# Altium schematic sheet 24210B_KitDevESP32Gui.SchDoc
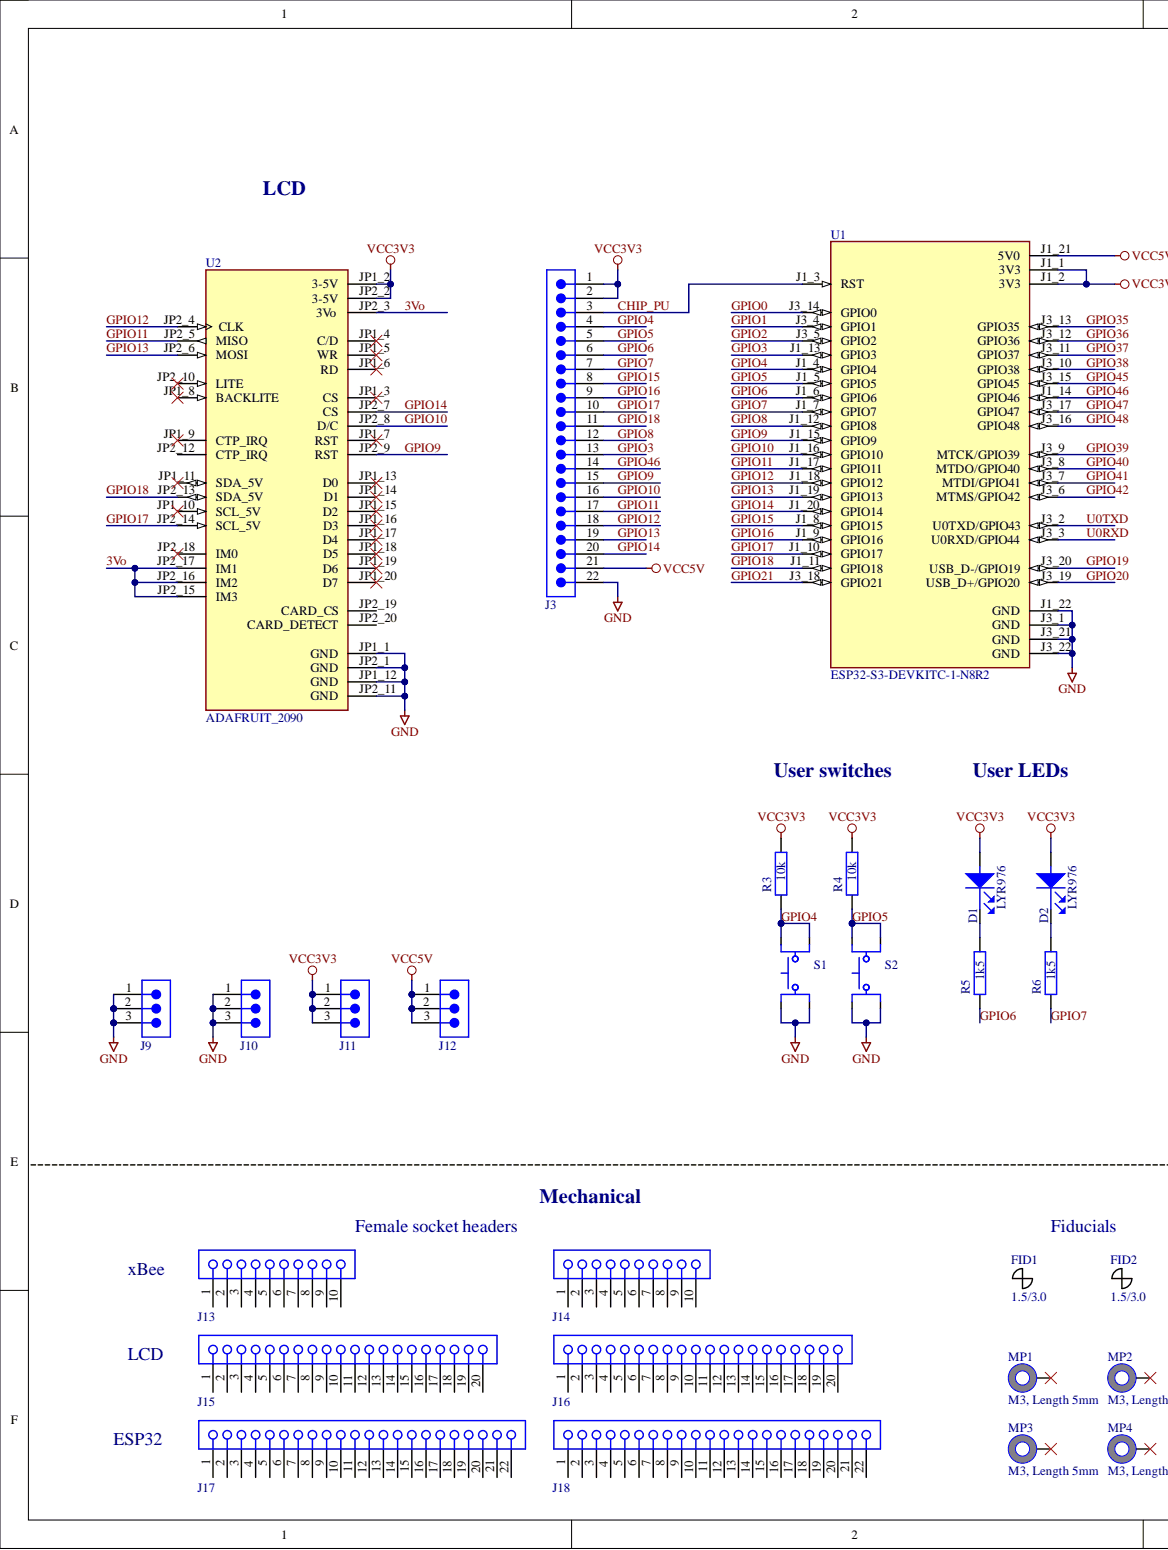
[diagram p0: 24210B_KitDevESP32Gui.SchDoc, left]
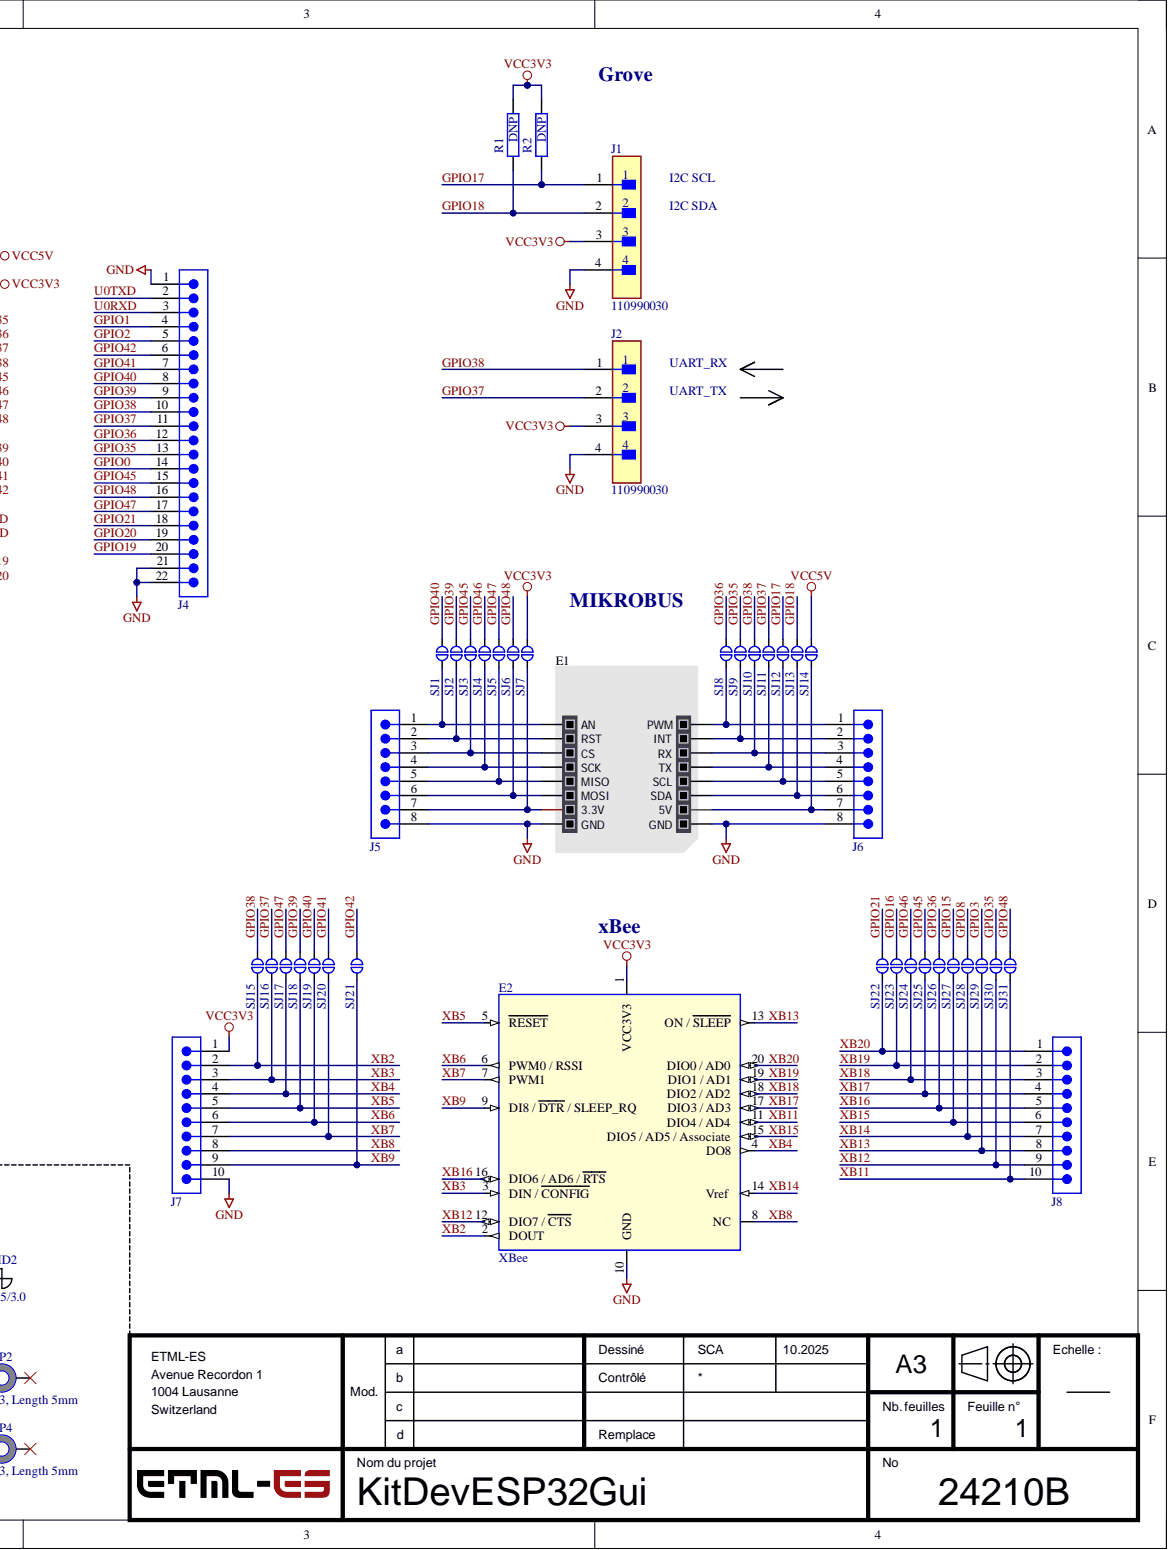
[diagram p1: 24210B_KitDevESP32Gui.SchDoc, right]

## Parts
D1  LYR976  [LED_0805]
D2  LYR976  [LED_0805]
E1  MIKROBUS HOST CONN.  [MIKROBUS HOST CONN. TH]  (value not drawn)
E2  XBee
FID1  1.5/3.0  [FID-150-300]  (no BOM)
FID2  1.5/3.0  SubCategory: Fiducials  [FID-150-300]  (no BOM)
J1  110990030  [FP-110990030-MFG]
J2  110990030  [FP-110990030-MFG]
J3  61302211121  [61302211121]  (value not drawn)
J4  61302211121  [61302211121]  (value not drawn)
J5  61300811121  [61300811121]  (value not drawn)
J6  61300811121  [61300811121]  (value not drawn)
J7  61301011121  [61301011121]  (value not drawn)
J8  61301011121  [61301011121]  (value not drawn)
J9  61300311121  [61300311121]  (value not drawn)
J10  61300311121  [61300311121]  (value not drawn)
J11  61300311121  [61300311121]  (value not drawn)
J12  61300311121  [61300311121]  (value not drawn)
J13  62001011821  [62001011821]  (value not drawn)  (mechanical)
J14  62001011821  [62001011821]  (value not drawn)  (mechanical)
J15  61302011821  [61302011821]  (value not drawn)  (mechanical)
J16  61302011821  [61302011821]  (value not drawn)  (mechanical)
J17  61302211821  [61302211821]  (value not drawn)  (mechanical)
J18  61302211821  [61302211821]  (value not drawn)  (mechanical)
MP1  M3, Length 5mm  [9774050360]
MP2  M3, Length 5mm  Length: 5mm  [9774050360]
MP3  M3, Length 5mm  [9774050360]
MP4  M3, Length 5mm  Length: 5mm  [9774050360]
R1  DNP  [RESC0805(2012)_N]
R2  DNP  [RESC0805(2012)_N]
R3  10k  [RESC0805(2012)_N]
R4  10k  [RESC0805(2012)_N]
R5  1k5  [RESC0805(2012)_N]
R6  1k5  [RESC0805(2012)_N]
S1  430182043816  [WS-TASV_L6W6H4.3_4301x20438x6]  (value not drawn)
S2  430182043816  [WS-TASV_L6W6H4.3_4301x20438x6]  (value not drawn)
SJ1  SOLDER JUMPER  [SOLDERJUMPER_OPENED]  (value not drawn)
SJ2  SOLDER JUMPER  [SOLDERJUMPER_OPENED]  (value not drawn)
SJ3  SOLDER JUMPER  [SOLDERJUMPER_OPENED]  (value not drawn)
SJ4  SOLDER JUMPER  [SOLDERJUMPER_OPENED]  (value not drawn)
SJ5  SOLDER JUMPER  [SOLDERJUMPER_OPENED]  (value not drawn)
SJ6  SOLDER JUMPER  [SOLDERJUMPER_OPENED]  (value not drawn)
SJ7  SOLDER JUMPER  [SOLDERJUMPER_OPENED]  (value not drawn)
SJ8  SOLDER JUMPER  [SOLDERJUMPER_OPENED]  (value not drawn)
SJ9  SOLDER JUMPER  [SOLDERJUMPER_OPENED]  (value not drawn)
SJ10  SOLDER JUMPER  [SOLDERJUMPER_OPENED]  (value not drawn)
SJ11  SOLDER JUMPER  [SOLDERJUMPER_OPENED]  (value not drawn)
SJ12  SOLDER JUMPER  [SOLDERJUMPER_OPENED]  (value not drawn)
SJ13  SOLDER JUMPER  [SOLDERJUMPER_OPENED]  (value not drawn)
SJ14  SOLDER JUMPER  [SOLDERJUMPER_OPENED]  (value not drawn)
SJ15  SOLDER JUMPER  [SOLDERJUMPER_OPENED]  (value not drawn)
SJ16  SOLDER JUMPER  [SOLDERJUMPER_OPENED]  (value not drawn)
SJ17  SOLDER JUMPER  [SOLDERJUMPER_OPENED]  (value not drawn)
SJ18  SOLDER JUMPER  [SOLDERJUMPER_OPENED]  (value not drawn)
SJ19  SOLDER JUMPER  [SOLDERJUMPER_OPENED]  (value not drawn)
SJ20  SOLDER JUMPER  [SOLDERJUMPER_OPENED]  (value not drawn)
SJ21  SOLDER JUMPER  [SOLDERJUMPER_OPENED]  (value not drawn)
SJ22  SOLDER JUMPER  [SOLDERJUMPER_OPENED]  (value not drawn)
SJ23  SOLDER JUMPER  [SOLDERJUMPER_OPENED]  (value not drawn)
SJ24  SOLDER JUMPER  [SOLDERJUMPER_OPENED]  (value not drawn)
SJ25  SOLDER JUMPER  [SOLDERJUMPER_OPENED]  (value not drawn)
SJ26  SOLDER JUMPER  [SOLDERJUMPER_OPENED]  (value not drawn)
SJ27  SOLDER JUMPER  [SOLDERJUMPER_OPENED]  (value not drawn)
SJ28  SOLDER JUMPER  [SOLDERJUMPER_OPENED]  (value not drawn)
SJ29  SOLDER JUMPER  [SOLDERJUMPER_OPENED]  (value not drawn)
SJ30  SOLDER JUMPER  [SOLDERJUMPER_OPENED]  (value not drawn)
SJ31  SOLDER JUMPER  [SOLDERJUMPER_OPENED]  (value not drawn)
U1  ESP32-S3-DEVKITC-1-N8R2  [XCVR_ESP32-S3-DEVKITC-1-N8R2_2]
U2  ADAFRUIT_2090  [MODULE_2090]

## Nets
GND: E1.8(GND) E1.9(GND) E2.10(GND) J1.4 J2.4 J3.22 J4.1 J4.21 J4.22 J5.8 J6.8 J7.10 J9.1 J9.2 J9.3 J10.1 J10.2 J10.3 S1.1 S1.3 S2.1 S2.3 U1.J1_22(GND) U1.J3_1(GND) U1.J3_21(GND) U1.J3_22(GND) U2.JP1_1(GND) U2.JP1_12(GND) U2.JP2_1(GND) U2.JP2_11(GND)
VCC3V3: D1.2 D2.2 E2.1(VCC3V3) J1.3 J2.3 J3.1 J3.2 J7.1 J11.1 J11.2 J11.3 R1.1 R2.1 R3.1 R4.1 SJ7.1 U1.J1_1(3V3) U1.J1_2(3V3) U2.JP1_2(3-5V) U2.JP2_2(3-5V)
VCC5V: J3.21 J12.1 J12.2 J12.3 SJ14.1 U1.J1_21(5V0)
3Vo: U2.JP2_3(3Vo) U2.JP2_15(IM3) U2.JP2_16(IM2) U2.JP2_17(IM1)
CHIP_PU: J3.3 U1.J1_3(RST)
GPIO0: J4.14 U1.J3_14(GPIO0)
GPIO1: J4.4 U1.J3_4(GPIO1)
GPIO2: J4.5 U1.J3_5(GPIO2)
GPIO3: J3.13 SJ29.1 U1.J1_13(GPIO3)
GPIO4: J3.4 R3.2 S1.2 S1.4 U1.J1_4(GPIO4)
GPIO5: J3.5 R4.2 S2.2 S2.4 U1.J1_5(GPIO5)
GPIO6: J3.6 R5.2 U1.J1_6(GPIO6)
GPIO7: J3.7 R6.2 U1.J1_7(GPIO7)
GPIO8: J3.12 SJ28.1 U1.J1_12(GPIO8)
GPIO9: J3.15 U1.J1_15(GPIO9) U2.JP2_9(RST)
GPIO10: J3.16 U1.J1_16(GPIO10) U2.JP2_8(D/C)
GPIO11: J3.17 U1.J1_17(GPIO11) U2.JP2_5(MISO)
GPIO12: J3.18 U1.J1_18(GPIO12) U2.JP2_4(CLK)
GPIO13: J3.19 U1.J1_19(GPIO13) U2.JP2_6(MOSI)
GPIO14: J3.20 U1.J1_20(GPIO14) U2.JP2_7(CS)
GPIO15: J3.8 SJ27.1 U1.J1_8(GPIO15)
GPIO16: J3.9 SJ23.1 U1.J1_9(GPIO16)
GPIO17: J1.1 J3.10 R2.2 SJ12.1 U1.J1_10(GPIO17) U2.JP2_14(SCL_5V)
GPIO18: J1.2 J3.11 R1.2 SJ13.1 U1.J1_11(GPIO18) U2.JP2_13(SDA_5V)
GPIO19: J4.20 U1.J3_20(USB_D-/GPIO19)
GPIO20: J4.19 U1.J3_19(USB_D+/GPIO20)
GPIO21: J4.18 SJ22.1 U1.J3_18(GPIO21)
GPIO35: J4.13 SJ9.1 SJ30.1 U1.J3_13(GPIO35)
GPIO36: J4.12 SJ8.1 SJ26.1 U1.J3_12(GPIO36)
GPIO37: J2.2 J4.11 SJ11.1 SJ16.1 U1.J3_11(GPIO37)
GPIO38: J2.1 J4.10 SJ10.1 SJ15.1 U1.J3_10(GPIO38)
GPIO39: J4.9 SJ2.1 SJ18.1 U1.J3_9(MTCK/GPIO39)
GPIO40: J4.8 SJ1.1 SJ19.1 U1.J3_8(MTDO/GPIO40)
GPIO41: J4.7 SJ20.1 U1.J3_7(MTDI/GPIO41)
GPIO42: J4.6 SJ21.1 U1.J3_6(MTMS/GPIO42)
GPIO45: J4.15 SJ3.1 SJ25.1 U1.J3_15(GPIO45)
GPIO46: J3.14 SJ4.1 SJ24.1 U1.J1_14(GPIO46)
GPIO47: J4.17 SJ5.1 SJ17.1 U1.J3_17(GPIO47)
GPIO48: J4.16 SJ6.1 SJ31.1 U1.J3_16(GPIO48)
U0RXD: J4.3 U1.J3_3(U0RXD/GPIO44)
U0TXD: J4.2 U1.J3_2(U0TXD/GPIO43)
XB2: E2.2(DOUT) J7.2 SJ15.2
XB3: E2.3(DIN_/_CONFIG) J7.3 SJ16.2
XB4: E2.4(DO8) J7.4 SJ17.2
XB5: E2.5(RESET) J7.5 SJ18.2
XB6: E2.6(PWM0_/_RSSI) J7.6 SJ19.2
XB7: E2.7(PWM1) J7.7 SJ20.2
XB8: E2.8(NC) J7.8
XB9: E2.9(DI8_/_DTR_/_SLEEP_RQ) J7.9 SJ21.2
XB11: E2.11(DIO4_/_AD4) J8.10 SJ31.2
XB12: E2.12(DIO7_/_CTS) J8.9 SJ30.2
XB13: E2.13(ON_/_SLEEP) J8.8 SJ29.2
XB14: E2.14(Vref) J8.7 SJ28.2
XB15: E2.15(DIO5_/_AD5_/_Associate) J8.6 SJ27.2
XB16: E2.16(DIO6_/_AD6_/_RTS) J8.5 SJ26.2
XB17: E2.17(DIO3_/_AD3) J8.4 SJ25.2
XB18: E2.18(DIO2_/_AD2) J8.3 SJ24.2
XB19: E2.19(DIO1_/_AD1) J8.2 SJ23.2
XB20: E2.20(DIO0_/_AD0) J8.1 SJ22.2
NetD1_1: D1.1 R5.1
NetD2_1: D2.1 R6.1
NetE1_1: E1.1(AN) J5.1 SJ1.2
NetE1_2: E1.2(RST) J5.2 SJ2.2
NetE1_3: E1.3(CS) J5.3 SJ3.2
NetE1_4: E1.4(SCK) J5.4 SJ4.2
NetE1_5: E1.5(MISO) J5.5 SJ5.2
NetE1_6: E1.6(MOSI) J5.6 SJ6.2
NetE1_7: E1.7(3.3V) J5.7 SJ7.2
NetE1_10: E1.10(5V) J6.7 SJ14.2
NetE1_11: E1.11(SDA) J6.6 SJ13.2
NetE1_12: E1.12(SCL) J6.5 SJ12.2
NetE1_13: E1.13(TX) J6.4 SJ11.2
NetE1_14: E1.14(RX) J6.3 SJ10.2
NetE1_15: E1.15(INT) J6.2 SJ9.2
NetE1_16: E1.16(PWM) J6.1 SJ8.2

Not connected: J13 1 2 3 4 5 6 7 8 9 10; J14 1 2 3 4 5 6 7 8 9 10; J15 1 2 3 4 5 6 7 8 9 10 11 12 13 14 15 16 17 18 19 20; J16 1 2 3 4 5 6 7 8 9 10 11 12 13 14 15 16 17 18 19 20; J17 1 2 3 4 5 6 7 8 9 10 11 12 13 14 15 16 17 18 19 20 21 22; J18 1 2 3 4 5 6 7 8 9 10 11 12 13 14 15 16 17 18 19 20 21 22; MP1 1; MP2 1; MP3 1; MP4 1; U2 JP1_3(CS) JP1_4(C/D) JP1_5(WR) JP1_6(RD) JP1_7(RST) JP1_8(BACKLITE) JP1_9(CTP_IRQ) JP1_10(SCL_5V) JP1_11(SDA_5V) JP1_13(D0) JP1_14(D1) JP1_15(D2) JP1_16(D3) JP1_17(D4) JP1_18(D5) JP1_19(D6) JP1_20(D7) JP2_10(LITE) JP2_12(CTP_IRQ) JP2_18(IM0) JP2_19(CARD_CS) JP2_20(CARD_DETECT)

## Library data of the parts
D1 D2 = LED_0: LED YELLOW DIFFUSED 0805 SMD; Lens Color: White; Lens Style: Flat; Case Code (Imperial): 0805; Case Code (Metric): 2012; Case/Package: 0805; Color: Yellow; Component Kind: Standard; Illumination Color: Yellow; Library Name: 11020E.SCHLIB; Mount: Surface Mount
E1 = MIKROBUS HOST CONN._1: mikroBUS Host Socket; Component Kind: Standard; Library Name: mikroBUS_socket_standard.SchLib; SMD: No
FID1 FID2 = CMP-063-000021-1: FID 1.5/3.0; IsStocked: False; PN: PN-509779; Category: Others; Library Name: ETML-ES; Value: 1.5/3.0
  FID1: SubCategory: Fiducials
J1 J2 = CMP-139044-000001-1: GROVE 2MM 4PIN VERT CONN 10PCS; Component Kind: Standard; Library Name: Altium Content Vault; Series: -
J3 J4 = 61302211121: WR-PHD Pin Header, THT, Vertical, pitch 2.54mm, 1 Row, 22P; Manufacturer: Wurth Elektronik; Contact Resistance: 20mOhm; Rated Current: 3A; Withstanding Voltage: 500V; Working Voltage: 250V; Category: Board-to-Board Connectors; Component Kind: Standard; ComponentLink1Description: Website Link; ComponentLink1URL: https://www.we-online.com/redexpert/article/61302211121?ad; ComponentLink2Description: Datasheet Link; ComponentLink2URL: https://www.we-online.com/redexpert/spec/61302211121?ad; Gender: Pin Header; Library Name: WR-PHD.SchLib; Manufacturer Part Number: 61302211121; Match Code: WR-PHD; Mount: Through Hole; Number of Pins: 22; Number of Rows: 1; Operating Temperature Max: 105°C; Operating Temperature Min: -40°C; Orientation: Vertical; Packaging: Bag; Pitch: 2.54mm
J5 J6 = 61300811121: WR-PHD Pin Header, THT, Vertical, pitch 2.54mm, 1 Row, 8P; Manufacturer: Wurth Elektronik; Contact Resistance: 20mOhm; Rated Current: 3A; Withstanding Voltage: 500V; Working Voltage: 250V; Category: Board-to-Board Connectors; Component Kind: Standard; ComponentLink1Description: Website Link; ComponentLink1URL: https://www.we-online.com/redexpert/article/61300811121?ad; ComponentLink2Description: Datasheet Link; ComponentLink2URL: https://www.we-online.com/redexpert/spec/61300811121?ad; Gender: Pin Header; Library Name: WR-PHD.SchLib; Manufacturer Part Number: 61300811121; Match Code: WR-PHD; Mount: Through Hole; Number of Pins: 8; Number of Rows: 1; Operating Temperature Max: 105°C; Operating Temperature Min: -40°C; Orientation: Vertical; Packaging: Bag; Pitch: 2.54mm
J7 J8 = 61301011121: WR-PHD Pin Header, THT, Vertical, pitch 2.54mm, 1 Row, 10P; Manufacturer: Wurth Elektronik; Contact Resistance: 20mOhm; Rated Current: 3A; Withstanding Voltage: 500V; Working Voltage: 250V; Category: Board-to-Board Connectors; Component Kind: Standard; ComponentLink1Description: Website Link; ComponentLink1URL: https://www.we-online.com/redexpert/article/61301011121?ad; ComponentLink2Description: Datasheet Link; ComponentLink2URL: https://www.we-online.com/redexpert/spec/61301011121?ad; Gender: Pin Header; Library Name: WR-PHD.SchLib; Manufacturer Part Number: 61301011121; Match Code: WR-PHD; Mount: Through Hole; Number of Pins: 10; Number of Rows: 1; Operating Temperature Max: 105°C; Operating Temperature Min: -40°C; Orientation: Vertical; Packaging: Bag; Pitch: 2.54mm
J9-J12 = 61300311121: WR-PHD Pin Header, THT, Vertical, pitch 2.54mm, 1 Row, 3P; Manufacturer: Wurth Elektronik; Contact Resistance: 20mOhm; Rated Current: 3A; Withstanding Voltage: 500V; Working Voltage: 250V; Category: Board-to-Board Connectors; Component Kind: Standard; ComponentLink1Description: Website Link; ComponentLink1URL: https://www.we-online.com/redexpert/article/61300311121?ad; ComponentLink2Description: Datasheet Link; ComponentLink2URL: https://www.we-online.com/redexpert/spec/61300311121?ad; Gender: Pin Header; Library Name: WR-PHD.SchLib; Manufacturer Part Number: 61300311121; Match Code: WR-PHD; Mount: Through Hole; Number of Pins: 3; Number of Rows: 1; Operating Temperature Max: 105°C; Operating Temperature Min: -40°C; Orientation: Vertical; Packaging: Bag; Pitch: 2.54mm
J13 J14 = 62001011821: WR-PHD Socket Header, THT, Vertical, pitch 2mm, 1 Row, 10P; Manufacturer: Wurth Elektronik; Contact Resistance: 20mOhm; Rated Current: 2A; Withstanding Voltage: 500V; Working Voltage: 250V; Category: Board-to-Board Connectors; ComponentLink1Description: Website Link; ComponentLink1URL: https://www.we-online.com/redexpert/article/62001011821?ad; ComponentLink2Description: Datasheet Link; ComponentLink2URL: https://www.we-online.com/redexpert/spec/62001011821?ad; Gender: Socket Header; Library Name: WR-PHD.SchLib; Manufacturer Part Number: 62001011821; Match Code: WR-PHD; Mount: Through Hole; Number of Pins: 10; Number of Rows: 1; Operating Temperature Max: 105°C; Operating Temperature Min: -40°C; Orientation: Vertical; Packaging: Tube; Pitch: 2mm
J15 J16 = 61302011821: WR-PHD Socket Header, THT, Vertical, pitch 2.54mm, 1 Row, 20P; Manufacturer: Wurth Elektronik; Contact Resistance: 20mOhm; Rated Current: 3A; Withstanding Voltage: 500V; Working Voltage: 250V; Category: Board-to-Board Connectors; ComponentLink1Description: Website Link; ComponentLink1URL: https://www.we-online.com/redexpert/article/61302011821?ad; ComponentLink2Description: Datasheet Link; ComponentLink2URL: https://www.we-online.com/redexpert/spec/61302011821?ad; Gender: Socket Header; Library Name: WR-PHD.SchLib; Manufacturer Part Number: 61302011821; Match Code: WR-PHD; Mount: Through Hole; Number of Pins: 20; Number of Rows: 1; Operating Temperature Max: 105°C; Operating Temperature Min: -40°C; Orientation: Vertical; Packaging: Tray; Pitch: 2.54mm
J17 J18 = 61302211821: WR-PHD Socket Header, THT, Vertical, pitch 2.54mm, 1 Row, 22P; Manufacturer: Wurth Elektronik; Contact Resistance: 20mOhm; Rated Current: 3A; Withstanding Voltage: 500V; Working Voltage: 250V; Category: Board-to-Board Connectors; ComponentLink1Description: Website Link; ComponentLink1URL: https://www.we-online.com/redexpert/article/61302211821?ad; ComponentLink2Description: Datasheet Link; ComponentLink2URL: https://www.we-online.com/redexpert/spec/61302211821?ad; Gender: Socket Header; Library Name: WR-PHD.SchLib; Manufacturer Part Number: 61302211821; Match Code: WR-PHD; Mount: Through Hole; Number of Pins: 22; Number of Rows: 1; Operating Temperature Max: 105°C; Operating Temperature Min: -40°C; Orientation: Vertical; Packaging: Tray; Pitch: 2.54mm
MP1-MP4 = 9774050360: WA-SMSI SMT Steel Spacer, M3 Thread Internal, Length 5mm; InnerDiameter: 4.2mm; OuterDiameter: 6mm; Component Kind: Standard; ComponentLink1Description: Manufacturer; ComponentLink1URL: http://www.we-online.de; ComponentLink2Description: Datasheet; ComponentLink2URL: http://katalog.we-online.de/em/datasheet/9774050360.pdf; Packaging: Bulk; PartNumber: 9774050360; PublishedDate: Dec. 2016; Supplier: Stock ES; Thread: M3; Publisher: Wurth Elektronik
  MP1 MP3: Length: 5mm
R1-R6 = R: Component Kind: Standard
  R1 R2: Value: DNP
  R3 R4: Value: 10k
  R5 R6: Value: 1k5
S1 S2 = 430182043816: WS-TASV SMT Tact Switch, L6 x W6 x H4.3mm, 160g, SPST-NO, 12V, 50mA; Manufacturer: Wurth Elektronik; Washable: No; Category: Tact Switches; Circuit: SPST-NO; Component Kind: Standard; ComponentLink1Description: Website Link; ComponentLink1URL: https://www.we-online.com/redexpert/article/430182043816?ad; ComponentLink2Description: Datasheet Link; ComponentLink2URL: https://www.we-online.com/redexpert/spec/430182043816?ad; Contact Material: Stainless Steel; Contact Plating: Silver; Height: 4.3mm; Length: 6mm; Library Name: 11020E.SCHLIB; Manufacturer Part Number: 430182043816; Match Code: WS-TASV; Mount: Surface Mount; Operating Temperature Max: 85°C; Operating Temperature Min: -40°C; Operation Force: 160g; Packaging: Tape and Reel; Rated Current: 50mA; Rated Voltage (DC): 12V; Terminal Material: Copper Alloy; Terminal Plating: Silver; Width: 6mm
SJ1-SJ31 = SOLDERJUMPER_OPENED: Solder JumperStandard SMD solder jumper. Used to automate production. Two varients : Normally Open and Normally Closed are the same, but have different paste layers. NC will have a large amount of paste and should jumper during reflow.; DeviceName: NO; GateName_1: 1; SymbolName_1: SJ; Component Kind: Standard; DeviceSetName: SOLDERJUMPER; Library Name: HCPBridgeMqttMini.SchLib
U1 = ESP32-S3-DEVKITC-1-N8R2: WiFi Development Tools - 802.11 (Engineering Samples Only) ESP32-S3 General-Purpose Development Board, ESP32-S3-WROOM-1-N8R2, with Pin Header; MF: Espressif Systems; Check_prices: https://www.snapeda.com/parts/ESP32-S3-DEVKITC-1-N8R2/Espressif+Systems/view-part/?ref=eda; Component Kind: Standard; Library Name: ESP32-S3-DEVKITC-1-N8R2.SchLib; MP: ESP32-S3-DEVKITC-1-N8R2; Package: None; SnapEDA_Link: https://www.snapeda.com/parts/ESP32-S3-DEVKITC-1-N8R2/Espressif+Systems/view-part/?ref=snap
U2 = 2090: MP: 1770; Availability: Unavailable; Component Kind: Standard; Library Name: Adafruit_LCD.SchLib; Package: None; Price: None
E2 = XBee: Component Kind: Standard; Library Name: 11020E.SCHLIB
